# Altium schematic sheet Sense Clock Ckt.SchDoc
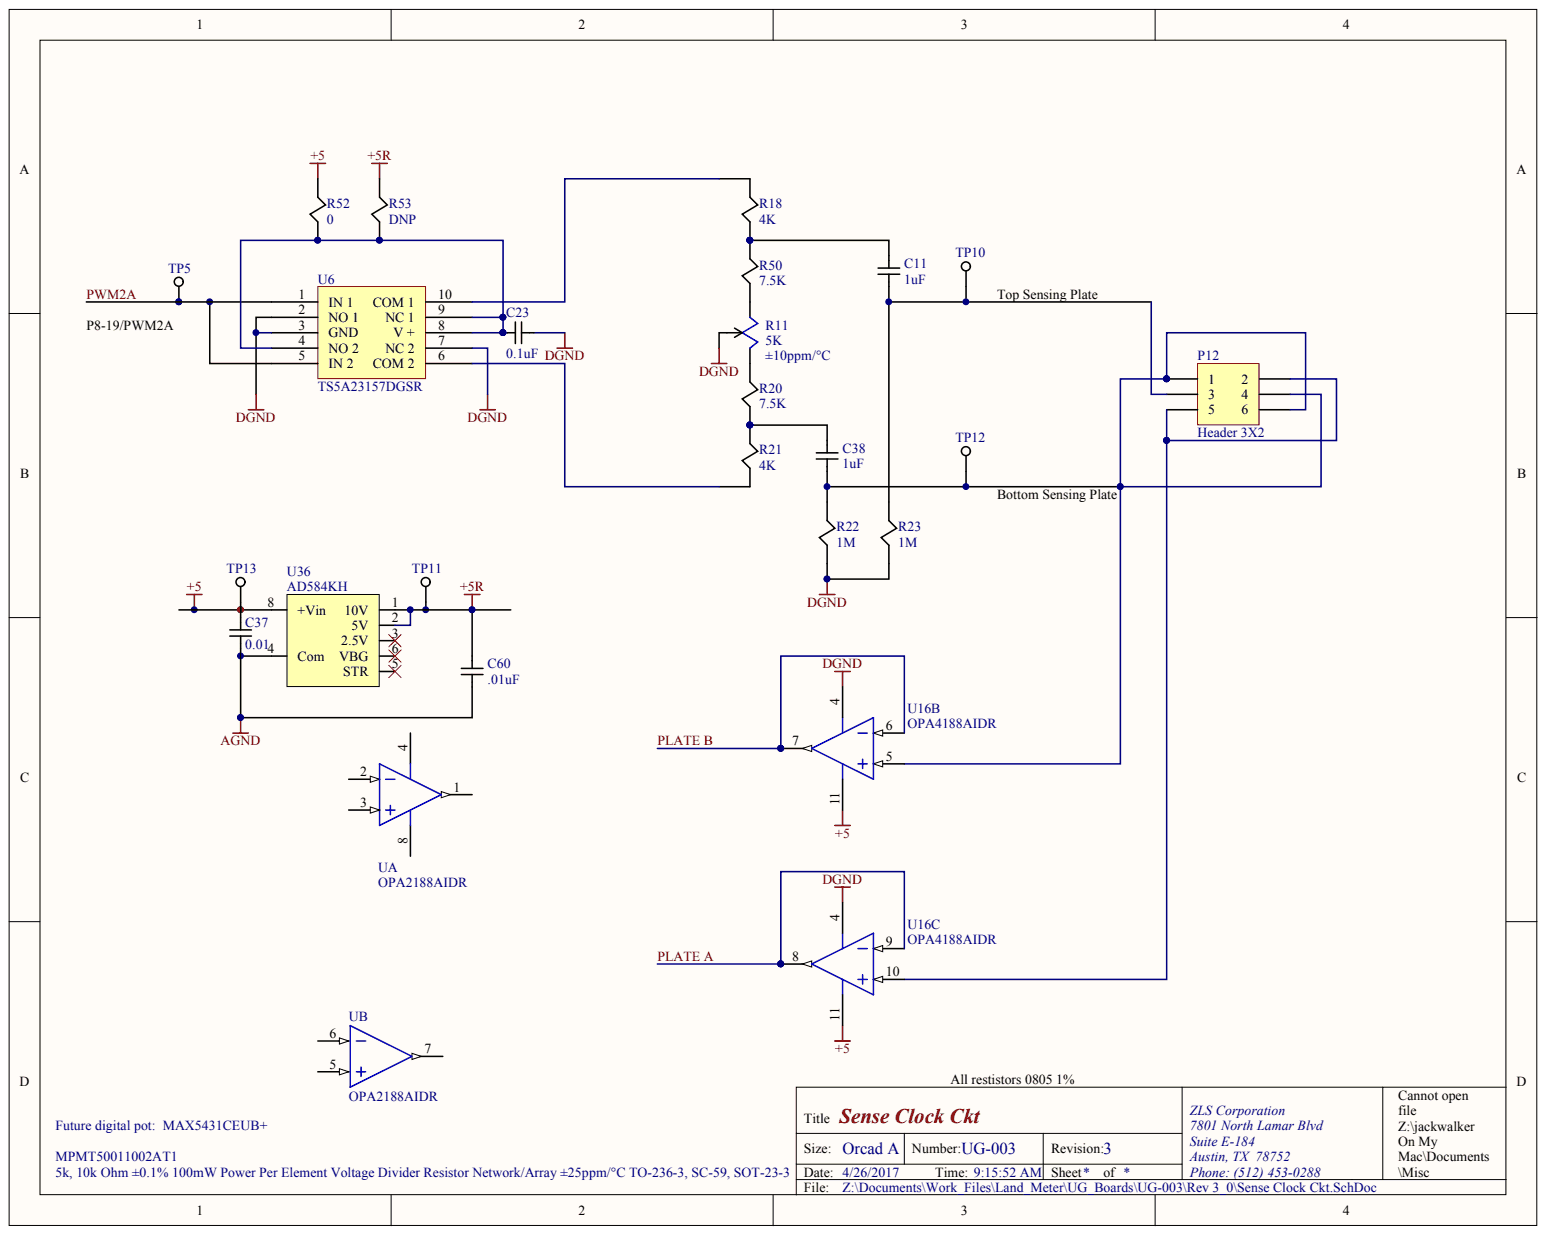
[diagram p0: Sense Clock Ckt.SchDoc]

## Parts
C11  1uF
C23  0.1uF
C37  0.01
C38  1uF
C60  .01uF
P12  Header 3X2  Connector Type: Header
R11  5K  Temperature Coefficient: ±10ppm/°C
R18  4K
R20  7.5K
R21  4K
R22  1M
R23  1M
R50  7.5K
R52  0
R53  DNP
TP5  (no value)
TP10  (no value)
TP11  (no value)
TP12  (no value)
TP13  (no value)
U  OPA2188AIDR  (parts A,B)
U6  TS5A23157DGSR
U16  OPA4188AIDR  (parts B,C)
U36  AD584KH

## Nets
+5: C37.1 R52.2 TP13.1 U16.11(+5) U36.8(+Vin)
+5R: C60.1 R53.2 TP11.1 U36.1(10V) U36.2(5V)
AGND: C37.2 C60.2 U36.4(Com)
DGND: C23.2 R11.3 R22.1 R23.1 U6.2(NO_1) U6.3(GND) U6.7(NC_2) U16.4
PLATE A: U16.8 U16.9
PLATE B: U16.6 U16.7
PWM2A: TP5.1 U6.1(IN_1) U6.5(IN_2)
NetC11_1: C11.1 R18.1 R50.2
NetC11_2: C11.2 P12.3 R23.2 TP10.1
NetC23_1: C23.1 R52.1 R53.1 U6.4(NO_2) U6.8(V_+) U6.9(NC_1)
NetC38_1: C38.1 R20.1 R21.2
NetC38_2: C38.2 P12.1 P12.4 P12.6 R22.2 TP12.1 U16.5
NetP12_2: P12.2 P12.5 U16.10
NetR11_1: R11.1 R50.1
NetR11_2: R11.2 R20.2
NetR18_2: R18.2 U6.10(COM_1)
NetR21_1: R21.1 U6.6(COM_2)

Not connected: U 1 2 3 4 5 6 7 8; U36 3(2.5V) 5(STR) 6(VBG)

## Library data of the parts
C11 C38 = (no symbol name): Manufacturer 1: Murata Electronics North America; Manufacturer Part Number 1: GRM21BR71E105KA99L; Description 1: CAP CER 1UF 25V X7R 0805; Category 1: Capacitors; Capacitance: 1µF; Tolerance: ±10%; Voltage - Rated: 25V; Temperature Coefficient: X7R; Supplier 1: Digi-Key; Supplier Part Number 1: 490-6484-1-ND; RoHS 1: RoHS Compliant; Stock 1: 110166; Pricing 1: 1=0.14, 10=0.099, 25=0.0724, 50=0.0564, 100=0.0468, 250=0.03872, 500=0.0328, 1000=0.02715 (USD); Packaging: Cut Tape (CT); Part Status: Active; Operating Temperature: -55°C ~ 125°C; Features: -; Ratings: -; Applications: General Purpose; Mounting Type: Surface Mount, MLCC; Package / Case: 0805 (2012 Metric); Size / Dimension: 0.079" L x 0.049" W (2.00mm x 1.25mm); Height - Seated (Max): -; Thickness (Max): 0.053" (1.35mm); Lead Spacing: -; Lead Style: -; ComponentLink1URL: http://www.murata.com/~/media/webrenewal/support/library/catalog/products/capacitor/mlcc/c02e.ashx; ComponentLink1Description: http://www.murata.com/~/media/webrenewal/support/library/catalog/products/capacitor/mlcc/c02e.ashx
C23 = (no symbol name): Manufacturer 1: Murata Electronics North America; Manufacturer Part Number 1: GRM219R71C104KA01D; Description 1: CAP CER 0.1UF 16V X7R 0805; Category 1: Capacitors; Capacitance: 0.1µF; Tolerance: ±10%; Voltage - Rated: 16V; Temperature Coefficient: X7R; Supplier 1: Digi-Key; Supplier Part Number 1: 490-1683-1-ND; RoHS 1: RoHS Compliant; Stock 1: 267626; Pricing 1: 1=0.13, 10=0.095, 25=0.0688, 50=0.0536, 100=0.0445, 250=0.0368, 500=0.03118, 1000=0.02581 (USD); Packaging: Cut Tape (CT); Part Status: Active; Operating Temperature: -55°C ~ 125°C; Features: -; Ratings: -; Applications: General Purpose; Mounting Type: Surface Mount, MLCC; Package / Case: 0805 (2012 Metric); Size / Dimension: 0.079" L x 0.049" W (2.00mm x 1.25mm); Height - Seated (Max): -; Thickness (Max): 0.037" (0.95mm); Lead Spacing: -; Lead Style: -; ComponentLink1URL: http://www.murata.com/~/media/webrenewal/support/library/catalog/products/capacitor/mlcc/c02e.ashx; ComponentLink1Description: http://www.murata.com/~/media/webrenewal/support/library/catalog/products/capacitor/mlcc/c02e.ashx
C37 = (no symbol name): Manufacturer 1: Murata Electronics North America; Manufacturer Part Number 1: GRM155R71H103KA88D; Description 1: CAP CER 10000PF 50V 10% X7R 0402; Category 1: Capacitors; Capacitance: 10000pF; Tolerance: ±10%; Voltage - Rated: 50V; Temperature Coefficient: X7R; Supplier 1: Digi-Key; Supplier Part Number 1: 490-4516-2-ND; RoHS 1: RoHS Compliant; Stock 1: 652600; Pricing 1: 10000=0.00372, 20000=0.00354, 30000=0.00336, 50000=0.00301, 100000=0.00243 (USD); Packaging: Tape & Reel (TR); Mounting Type: Surface Mount, MLCC; Operating Temperature: -55°C ~ 125°C; Applications: General Purpose; Ratings: -; Package / Case: 0402 (1005 Metric); Size / Dimension: 0.039" L x 0.020" W (1.00mm x 0.50mm); Height - Seated (Max): -; Thickness (Max): 0.022" (0.55mm); Lead Spacing: -; Features: -; Lead Style: -; ComponentLink1URL: http://www.murata.com/products/catalog/pdf/c02e.pdf; ComponentLink1Description: http://www.murata.com/products/catalog/pdf/c02e.pdf
C60 = (no symbol name): Manufacturer 1: Murata Electronics North America; Manufacturer Part Number 1: GRM216R71H103KA01D; Description 1: CAP CER 10000PF 50V X7R 0805; Category 1: Capacitors; Capacitance: 10000pF; Tolerance: ±10%; Voltage - Rated: 50V; Temperature Coefficient: X7R; Supplier 1: Digi-Key; Supplier Part Number 1: 490-1664-1-ND; RoHS 1: RoHS Compliant; Stock 1: 1003025; Pricing 1: 1=0.1, 10=0.072, 25=0.052, 50=0.0392, 100=0.032, 250=0.02672, 500=0.02282, 1000=0.01793 (USD); Packaging: Cut Tape (CT); Part Status: Active; Operating Temperature: -55°C ~ 125°C; Features: -; Ratings: -; Applications: General Purpose; Mounting Type: Surface Mount, MLCC; Package / Case: 0805 (2012 Metric); Size / Dimension: 0.079" L x 0.049" W (2.00mm x 1.25mm); Height - Seated (Max): -; Thickness (Max): 0.028" (0.70mm); Lead Spacing: -; Lead Style: -; ComponentLink1URL: http://www.murata.com/~/media/webrenewal/support/library/catalog/products/capacitor/mlcc/c02e.ashx; ComponentLink1Description: http://www.murata.com/~/media/webrenewal/support/library/catalog/products/capacitor/mlcc/c02e.ashx
P12 = (no symbol name): Manufacturer 1: Amphenol FCI; Manufacturer Part Number 1: 861400062YO2LF; Description 1: HEADER BERGSTIK; Category 1: Connectors, Interconnects; Pitch - Mating: 0.100" (2.54mm); Style: Board to Board or Cable; Shrouding: Unshrouded; Number of Positions: 6; Number of Positions Loaded: All; Contact Length - Mating: 0.276" (7.00mm); Contact Length - Post: 0.118" (3.00mm); Overall Contact Length: 0.496" (12.60mm); Material Flammability Rating: UL94 V-0; Current Rating: 3A; Voltage Rating: 1000V; Supplier 1: Digi-Key; Supplier Part Number 1: 861400062YO2LF-ND; RoHS 1: RoHS Compliant; Stock 1: 0; Pricing 1: 6000=0.09952 (USD); Packaging: Bulk; Part Status: Active; Contact Type: Male Pin; Number of Rows: 2; Row Spacing - Mating: 0.100" (2.54mm); Mounting Type: Through Hole; Termination: Solder; Fastening Type: -; Insulation Height: 0.102" (2.60mm); Contact Shape: Square; Contact Finish - Mating: Gold or Gold, GXT™; Contact Finish Thickness - Mating: 8µin (0.20µm); Contact Finish - Post: -; Contact Material: Copper Alloy; Insulation Material: Thermoplastic; Features: -; Operating Temperature: -55°C ~ 125°C; Ingress Protection: -; Insulation Color: -; Mated Stacking Heights: -; Contact Finish Thickness - Post: -; Applications: -; ComponentLink1URL: http://portal.fciconnect.com/Comergent//fci/drawing/c-8614-0086.pdf; ComponentLink1Description: http://portal.fciconnect.com/Comergent//fci/drawing/c-8614-0086.pdf
R11 = (no symbol name): Manufacturer 1: Vishay Foil Resistors (Division of Vishay Precision Group); Manufacturer Part Number 1: Y00535K00000J0L; Description 1: TRIMMER 5K OHM 0.25W PC PIN; Category 1: Potentiometers, Variable Resistors; Power (Watts): 0.25W, 1/4W; Tolerance: ±5%; Number of Turns: 21; Adjustment Type: Side Adjustment; Resistive Material: Metal Foil; Supplier 1: Digi-Key; Supplier Part Number 1: 804-1020-ND; RoHS 1: RoHS Compliant; Stock 1: 679; Pricing 1: 1=34.42, 10=32.265, 25=26.5292, 50=22.944, 100=22.227, 250=20.793, 500=19.2156 (USD); Packaging: Bulk; Part Status: Active; Resistance (Ohms): 5k; Mounting Type: Through Hole; Termination Style: PC Pins; Size / Dimension: Rectangular - 0.250" x 0.175" Face x 0.250" L (6.35mm x 4.45mm x 6.35mm); ComponentLink1URL: http://www.vishaypg.com/docs/63053/1240.pdf; ComponentLink1Description: http://www.vishaypg.com/docs/63053/1240.pdf
R18 R21 = (no symbol name): Manufacturer 1: Vishay Dale; Manufacturer Part Number 1: CRCW08056K98FKEA; Description 1: RES SMD 6.98K OHM 1% 1/8W 0805; Category 1: Resistors; Resistance (Ohms): 6.98k; Tolerance: ±1%; Power (Watts): 0.125W, 1/8W; Temperature Coefficient: ±100ppm/°C; ComponentLink1URL: *; Manufacturer Part Nunber 1: *; Package/ Case: 0805 (2012 metric); Supplier 1: Digi-Key; Supplier Part Number 1: 541-6.98KCCT-ND; RoHS 1: RoHS Compliant; Stock 1: 39695; Pricing 1: 1=0.1, 10=0.042, 25=0.0304, 50=0.0232, 100=0.017, 250=0.013, 500=0.0104, 1000=0.00765 (USD); Packaging: Cut Tape (CT); Part Status: Active; Composition: Thick Film; Features: Automotive AEC-Q200; Operating Temperature: -55°C ~ 155°C; Package / Case: 0805 (2012 Metric); Supplier Device Package: 0805; Size / Dimension: 0.079" L x 0.049" W (2.00mm x 1.25mm); Height - Seated (Max): 0.020" (0.50mm); Failure Rate: -; ComponentLink2URL: http://www.vishay.com/docs/20035/dcrcwe3.pdf; ComponentLink2Description: http://www.vishay.com/docs/20035/dcrcwe3.pdf
R20 R50 = (no symbol name): Manufacturer 1: Vishay Dale; Manufacturer Part Number 1: CRCW08057K50FKEA; Description 1: RES SMD 7.5K OHM 1% 1/8W 0805; Category 1: Resistors; Tolerance: ±1%; Power (Watts): 0.125W, 1/8W; Temperature Coefficient: ±100ppm/°C; ComponentLink1URL: *; Manufacturer Part Nunber 1: *; Package/ Case: 0805 (2012 metric); Supplier 1: Digi-Key; Supplier Part Number 1: 541-7.50KCCT-ND; RoHS 1: RoHS Compliant; Stock 1: 4466; Pricing 1: 1=0.1, 10=0.042, 25=0.0304, 50=0.0232, 100=0.017, 250=0.013, 500=0.0104, 1000=0.00765 (USD); Packaging: Cut Tape (CT); Part Status: Active; Resistance (Ohms): 7.5k; Composition: Thick Film; Features: Automotive AEC-Q200; Operating Temperature: -55°C ~ 155°C; Package / Case: 0805 (2012 Metric); Supplier Device Package: 0805; Size / Dimension: 0.079" L x 0.049" W (2.00mm x 1.25mm); Height - Seated (Max): 0.020" (0.50mm); Failure Rate: -; ComponentLink2URL: http://www.vishay.com/docs/20035/dcrcwe3.pdf; ComponentLink2Description: http://www.vishay.com/docs/20035/dcrcwe3.pdf
R22 R23 = (no symbol name): Manufacturer 1: Vishay Dale; Manufacturer Part Number 1: CRCW08051M00FKEA; Description 1: RES SMD 1M OHM 1% 1/8W 0805; Category 1: Resistors; Tolerance: ±1%; Power (Watts): 0.125W, 1/8W; Temperature Coefficient: ±100ppm/°C; ComponentLink1URL: *; Manufacturer Part Nunber 1: *; Package/ Case: 0805 (2012 metric); Supplier 1: Digi-Key; Supplier Part Number 1: 541-1.00MCDKR-ND; RoHS 1: RoHS Compliant; Stock 1: 44541; Pricing 1: 1=0.1, 10=0.042, 25=0.0304, 50=0.0232, 100=0.017, 250=0.013, 500=0.0104, 1000=0.00765 (USD); Packaging: Digi-Reel®; Part Status: Active; Resistance (Ohms): 1M; Composition: Thick Film; Features: Automotive AEC-Q200; Operating Temperature: -55°C ~ 155°C; Package / Case: 0805 (2012 Metric); Supplier Device Package: 0805; Size / Dimension: 0.079" L x 0.049" W (2.00mm x 1.25mm); Height - Seated (Max): 0.020" (0.50mm); Failure Rate: -; ComponentLink2URL: http://www.vishay.com/docs/20035/dcrcwe3.pdf; ComponentLink2Description: http://www.vishay.com/docs/20035/dcrcwe3.pdf
R52 R53 = (no symbol name): Manufacturer 1: Vishay Dale; Manufacturer Part Number 1: CRCW08050000Z0EA; Description 1: RES SMD 0.0 OHM JUMPER 1/8W 0805; Category 1: Resistors; Resistance (Ohms): 0.0; Tolerance: Jumper; Power (Watts): 0.125W, 1/8W; Supplier 1: Digi-Key; Supplier Part Number 1: 541-0.0ACT-ND; RoHS 1: RoHS Compliant; Stock 1: 1008635; Pricing 1: 1=0.1, 10=0.026, 25=0.0184, 50=0.014, 100=0.0104, 250=0.00792, 500=0.00634, 1000=0.00466 (USD); Packaging: Cut Tape (CT); Part Status: Active; Composition: Thick Film; Features: Automotive AEC-Q200; Temperature Coefficient: -; Operating Temperature: -55°C ~ 155°C; Package / Case: 0805 (2012 Metric); Supplier Device Package: 0805; Size / Dimension: 0.079" L x 0.049" W (2.00mm x 1.25mm); Height - Seated (Max): 0.020" (0.50mm); Failure Rate: -; ComponentLink1URL: http://www.vishay.com/docs/20035/dcrcwe3.pdf; ComponentLink1Description: http://www.vishay.com/docs/20035/dcrcwe3.pdf
TP5 TP10 TP12 = (no symbol name): Manufacturer 1: Keystone Electronics; Manufacturer Part Number 1: 5001; Description 1: TEST POINT PC MINI .040"D BLACK; Category 1: Test and Measurement; Plating: Silver; Material - Insulation: Nylon 46, UL 94V-0; Material - Body: Phosphor Bronze; Supplier 1: Digi-Key; Supplier Part Number 1: 36-5001-ND; RoHS 1: RoHS Compliant; Stock 1: 883882; Pricing 1: 1=0.37, 10=0.316, 50=0.2298, 100=0.2209, 250=0.19832, 500=0.18932, 1000=0.1616, 2500=0.14424, 5000=0.13523 (USD); Packaging: Bulk; Part Status: Active; Type: PC Test Point, Miniature; Mounting Type: 0.040" (1.02mm) Hole Diameter; Color: Black; Size / Dimension: 0.100" Dia x 0.180" L (2.54mm x 4.57mm); Height: -; ComponentLink1URL: http://www.keyelco.com/product-pdf.cfm?p=1310; ComponentLink1Description: http://www.keyelco.com/product-pdf.cfm?p=1310
U6 = (no symbol name): Manufacturer 1: Texas Instruments; Description 1: IC SWITCH DUAL SPDT 10MSOP; Category 1: Integrated Circuits (ICs); Switch Circuit: SPDT; Multiplexer/Demultiplexer Circuit: 2:1; Number of Circuits: 2; On-State Resistance (Max): 10 Ohm; Channel-to-Channel Matching (&Delta;Ron): 150 mOhm; Voltage - Supply, Single (V+): 1.65 V ~ 5.5 V; Switch Time (Ton, Toff) (Max): 5.7ns, 3.8ns; -3db Bandwidth: 220MHz; Charge Injection: 7pC; Channel Capacitance (CS(off), CD(off)): 5.5pF; Current - Leakage (IS(off)) (Max): 1µA; Crosstalk: -66dB @ 10MHz; Supplier 1: Digi-Key; Supplier Part Number 1: 296-17076-1-ND; Manufacturer Part Number 1: TS5A23157DGSR; RoHS 1: RoHS Compliant; Stock 1: 10674; Pricing 1: 1=0.89, 10=0.782, 100=0.5994, 500=0.4738, 1000=0.37904 (USD); Packaging: Cut Tape (CT); Part Status: Active; Voltage - Supply, Dual (V±): -; Operating Temperature: -40°C ~ 85°C (TA); Package / Case: 10-TFSOP, 10-MSOP (0.118", 3.00mm Width); Supplier Device Package: 10-VSSOP; ComponentLink1URL: http://www.ti.com/lit/ds/symlink/ts5a23157.pdf; ComponentLink1Description: http://www.ti.com/lit/ds/symlink/ts5a23157.pdf
U16 = (no symbol name): Manufacturer: Texas Instruments; CMRR(Min)(dB): 120; Eco-Plan: Green (RoHS & no Sb/Br); GBW(Typ)(MHz): 2; Iq per channel(Max)(mA): 0.475; Number of Channels: 4; Offset Drift(Typ)(uV/C): 0.03; Packing: LARGE T&R; Rating: Catalog; Slew Rate(Typ)(V/us): 0.8; SubFamily: High Supply Voltage Amplifier (>=30V), Low Noise Amp (<=10nV/rtHz), Low Offset Voltage Amplifier (<=500uV), Low Power Amp (<=500uA), Zero Drift Precision Amplifier; Total Supply Voltage(Max)(+5V is 5, +/-5V is 10): 36; DatasheetVersion: SBOS641B; PackageVersion: 4040047-5/M, 06/2011; PackageReference: D14; PackageDescription: 14-Pin Plastic Small Outline, Body 8.65 x 3.9 mm, Pitch 1.27 mm; Supplier 1: Digi-Key; Supplier Part Number 1: 296-30574-2-ND; Supplier 2: Digi-Key; Supplier Part Number 2: 296-30574-1-ND; Supplier 3: Digi-Key; Supplier Part Number 3: 296-30574-6-ND; Supplier 4: Newark; Supplier Part Number 4: 27W9606; Supplier 5: Mouser; Supplier Part Number 5: 595-OPA4188AIDR; Total Supply Voltage(Min)(+5V is 5, +/-5V is 10): 4; Mounting Technology: Surface Mount; Code_JEDEC: MS-012; PartNumber: OPA4188AIDR; ComponentLink1Description: Manufacturer URL; ComponentLink1URL: http://www.ti.com/; ComponentLink2Description: Datasheet; ComponentLink2URL: http://www.ti.com/lit/ds/symlink/opa4188.pdf; ComponentLink3Description: Package Specification; ComponentLink3URL: http://www.ti.com/lit/ml/mpds177g/mpds177g.pdf
U36 = (no symbol name): Manufacturer 1: Analog Devices Inc.; Description 1: IC VREF SERIES PROG TO99-8; Category 1: Integrated Circuits (ICs); Reference Type: Series; Output Type: Programmable; Voltage - Output (Min/Fixed): 2.5V, 5V, 7.5V, 10V; Current - Output: 10mA; Tolerance: ±0.1%; Temperature Coefficient: 15ppm/°C; Noise - 0.1Hz to 10Hz: 50µVp-p; Voltage - Input: 4.5 V ~ 30 V; Current - Supply: 1mA; Supplier 1: Digi-Key; Supplier Part Number 1: AD584KH-ND; Manufacturer Part Number 1: AD584KH; RoHS 1: RoHS non-compliant; Stock 1: 133; Pricing 1: 1=30.28, 10=27.928, 100=23.8488 (USD); Packaging: Bulk; Part Status: Active; Voltage - Output (Max): -; Noise - 10Hz to 10kHz: -; Current - Cathode: -; Operating Temperature: 0°C ~ 70°C (TA); Mounting Type: Through Hole; Package / Case: TO-99-8 Metal Can; Supplier Device Package: TO-99-8; ComponentLink1URL: http://www.analog.com/media/en/technical-documentation/data-sheets/AD584.pdf; ComponentLink1Description: http://www.analog.com/media/en/technical-documentation/data-sheets/AD584.pdf
